# Altium schematic sheet MCU_SysBoard.SchDoc(MCU_SysBoard)
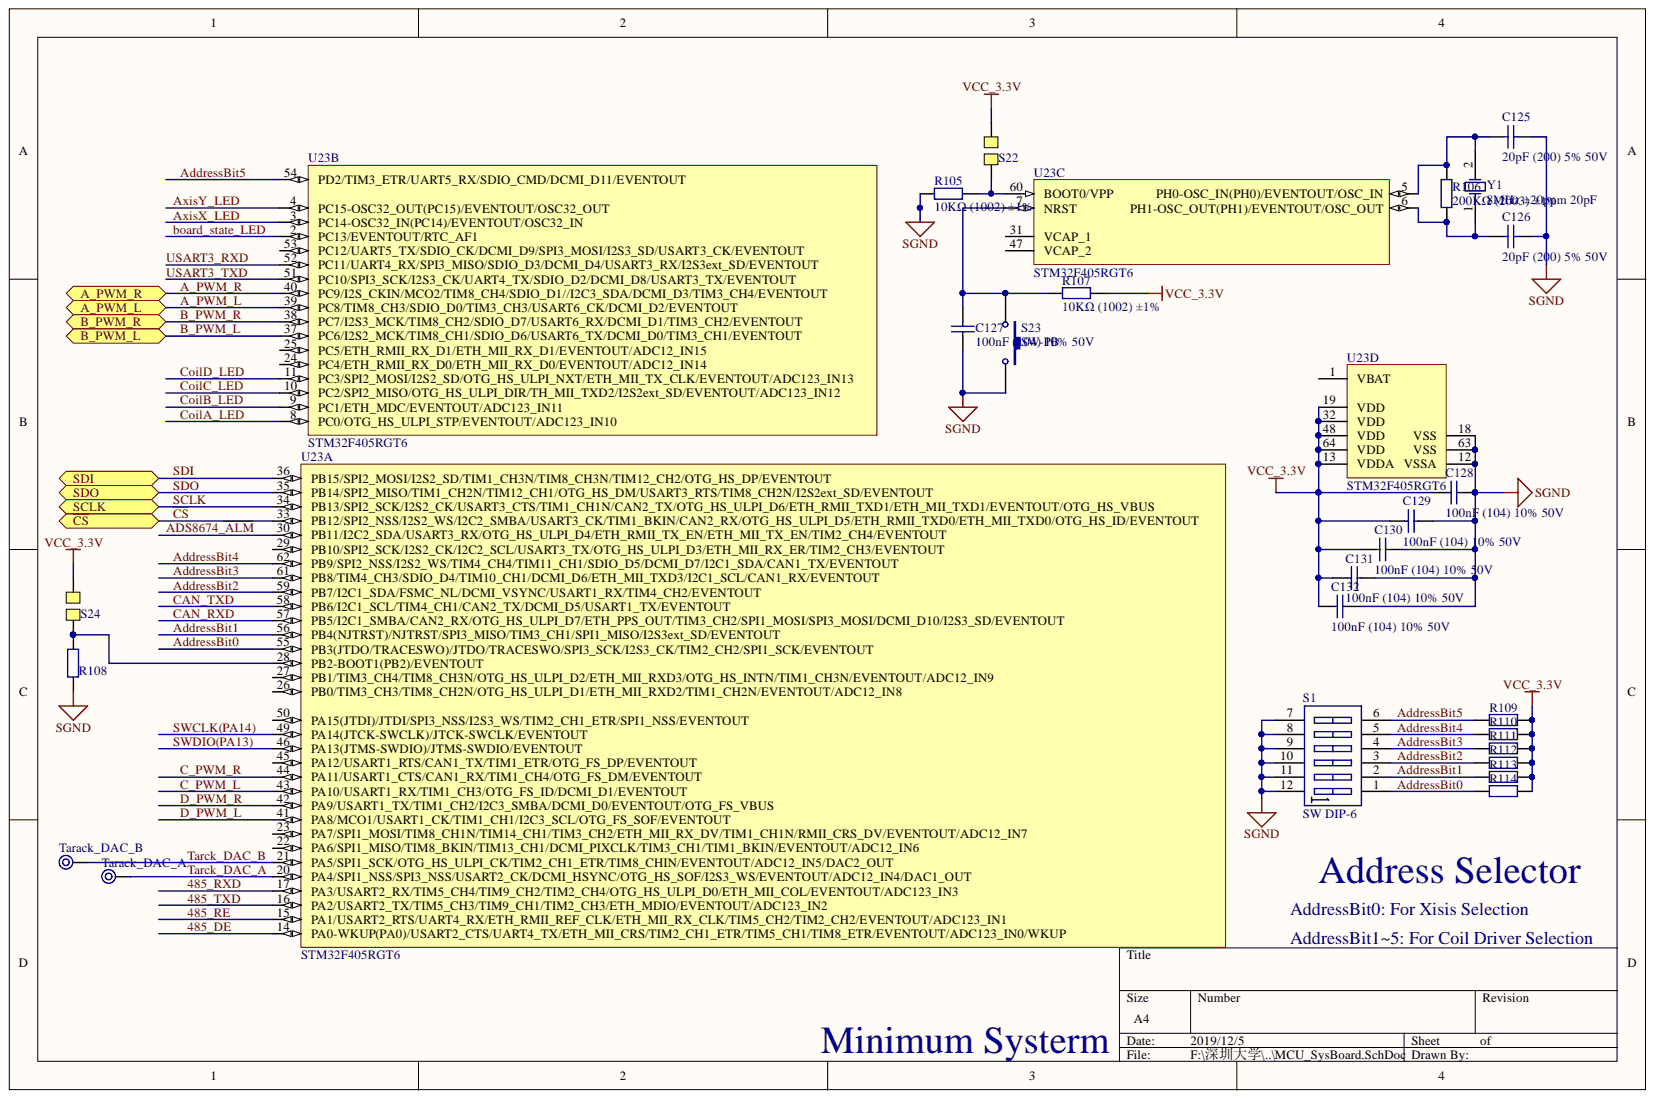
[diagram p0: MCU_SysBoard.SchDoc]

## Parts
C125  20pF (200) 5% 50V  [0402_C]
C126  20pF (200) 5% 50V  [0402_C]
C127  100nF (104) 10% 50V  [0603_C]
C128  100nF (104) 10% 50V  [0603_C]
C129  100nF (104) 10% 50V  [0603_C]
C130  100nF (104) 10% 50V  [0603_C]
C131  100nF (104) 10% 50V  [0603_C]
C132  100nF (104) 10% 50V  [0603_C]
R105  10KΩ (1002) ±1%  [0402_R]
R106  200KΩ (2003) ±1%  [0402_R]
R107  10KΩ (1002) ±1%  [0603_R]
R108  10KΩ (1002) ±1%  [0402_R]
R109  10KΩ (1002) ±1%  [0603_R]
R110  10KΩ (1002) ±1%  [0603_R]
R111  10KΩ (1002) ±1%  [0603_R]
R112  10KΩ (1002) ±1%  [0603_R]
R113  10KΩ (1002) ±1%  [0603_R]
R114  10KΩ (1002) ±1%  [0603_R]
S1  SW DIP-6  [DIP-12/SW]
S22  SHORT-2PIN  [SHORT-2PIN]  (value not drawn)
S23  SW-PB  [SW_PB2_A1]
S24  SHORT-2PIN  [SHORT-2PIN]  (value not drawn)
Tarack_DAC_A  TP_Surface  [TEST_POINT_ME]  (value not drawn)
Tarack_DAC_B  TP_Surface  [TEST_POINT_ME]  (value not drawn)
U23  STM32F405RGT6  [LQFP-64_10x10x05P]  (parts A,B,C,D)
Y1  8MHz ±20ppm 20pF  [SMD-5032_2P]

## Ports
A_PWM_L, A_PWM_R, B_PWM_L, B_PWM_R, SCLK, SDI, SDO, ~{CS}

## Nets
SGND: C125.2 C126.2 C127.2 C128.1 C129.1 C130.1 C131.1 C132.1 R105.1 R108.1 S1.7 S1.8 S1.9 S1.10 S1.11 S1.12 S23.2 U23.12(VSSA) U23.18(VSS) U23.63(VSS)
VCC_3.3V: C128.2 C129.2 C130.2 C131.2 C132.2 R107.2 R109.1 R110.1 R111.1 R112.1 R113.1 R114.1 S22.2 S24.2 U23.13(VDDA) U23.19(VDD) U23.32(VDD) U23.48(VDD) U23.64(VDD)
485_DE: U23.14(PA0-WKUP(PA0)/USART2_CTS/UART4_TX/ETH_MII_CRS/TIM2_CH1_ETR/TIM5_CH1/TIM8_ETR/EVENTOUT/ADC123_IN0/WKUP)
485_~{RE}: U23.15(PA1/USART2_RTS/UART4_RX/ETH_RMII_REF_CLK/ETH_MII_RX_CLK/TIM5_CH2/TIM2_CH2/EVENTOUT/ADC123_IN1)
485_RXD: U23.17(PA3/USART2_RX/TIM5_CH4/TIM9_CH2/TIM2_CH4/OTG_HS_ULPI_D0/ETH_MII_COL/EVENTOUT/ADC123_IN3)
485_TXD: U23.16(PA2/USART2_TX/TIM5_CH3/TIM9_CH1/TIM2_CH3/ETH_MDIO/EVENTOUT/ADC123_IN2)
A_PWM_L: U23.39(PC8/TIM8_CH3/SDIO_D0/TIM3_CH3/USART6_CK/DCMI_D2/EVENTOUT) ; port A_PWM_L
A_PWM_R: U23.40(PC9/I2S_CKIN/MCO2/TIM8_CH4/SDIO_D1//I2C3_SDA/DCMI_D3/TIM3_CH4/EVENTOUT) ; port A_PWM_R
AddressBit0: R114.2 S1.1 U23.55(PB3(JTDO/TRACESWO)/JTDO/TRACESWO/SPI3_SCK/I2S3_CK/TIM2_CH2/SPI1_SCK/EVENTOUT)
AddressBit1: R113.2 S1.2 U23.56(PB4(NJTRST)/NJTRST/SPI3_MISO/TIM3_CH1/SPI1_MISO/I2S3ext_SD/EVENTOUT)
AddressBit2: R112.2 S1.3 U23.59(PB7/I2C1_SDA/FSMC_NL/DCMI_VSYNC/USART1_RX/TIM4_CH2/EVENTOUT)
AddressBit3: R111.2 S1.4 U23.61(PB8/TIM4_CH3/SDIO_D4/TIM10_CH1/DCMI_D6/ETH_MII_TXD3/I2C1_SCL/CAN1_RX/EVENTOUT)
AddressBit4: R110.2 S1.5 U23.62(PB9/SPI2_NSS/I2S2_WS/TIM4_CH4/TIM11_CH1/SDIO_D5/DCMI_D7/I2C1_SDA/CAN1_TX/EVENTOUT)
AddressBit5: R109.2 S1.6 U23.54(PD2/TIM3_ETR/UART5_RX/SDIO_CMD/DCMI_D11/EVENTOUT)
ADS8674_~{ALM}: U23.30(PB11/I2C2_SDA/USART3_RX/OTG_HS_ULPI_D4/ETH_RMII_TX_EN/ETH_MII_TX_EN/TIM2_CH4/EVENTOUT)
AxisX_LED: U23.3(PC14-OSC32_IN(PC14)/EVENTOUT/OSC32_IN)
AxisY_LED: U23.4(PC15-OSC32_OUT(PC15)/EVENTOUT/OSC32_OUT)
B_PWM_L: U23.37(PC6/I2S2_MCK/TIM8_CH1/SDIO_D6/USART6_TX/DCMI_D0/TIM3_CH1/EVENTOUT) ; port B_PWM_L
B_PWM_R: U23.38(PC7/I2S3_MCK/TIM8_CH2/SDIO_D7/USART6_RX/DCMI_D1/TIM3_CH2/EVENTOUT) ; port B_PWM_R
board_state_LED: U23.2(PC13/EVENTOUT/RTC_AF1)
~{CS}: U23.33(PB12/SPI2_NSS/I2S2_WS/I2C2_SMBA/USART3_CK/TIM1_BKIN/CAN2_RX/OTG_HS_ULPI_D5/ETH_RMII_TXD0/ETH_MII_TXD0/OTG_HS_ID/EVENTOUT) ; port ~{CS}
C_PWM_L: U23.43(PA10/USART1_RX/TIM1_CH3/OTG_FS_ID/DCMI_D1/EVENTOUT)
C_PWM_R: U23.44(PA11/USART1_CTS/CAN1_RX/TIM1_CH4/OTG_FS_DM/EVENTOUT)
CAN_RXD: U23.57(PB5/I2C1_SMBA/CAN2_RX/OTG_HS_ULPI_D7/ETH_PPS_OUT/TIM3_CH2/SPI1_MOSI/SPI3_MOSI/DCMI_D10/I2S3_SD/EVENTOUT)
CAN_TXD: U23.58(PB6/I2C1_SCL/TIM4_CH1/CAN2_TX/DCMI_D5/USART1_TX/EVENTOUT)
CoilA_LED: U23.8(PC0/OTG_HS_ULPI_STP/EVENTOUT/ADC123_IN10)
CoilB_LED: U23.9(PC1/ETH_MDC/EVENTOUT/ADC123_IN11)
CoilC_LED: U23.10(PC2/SPI2_MISO/OTG_HS_ULPI_DIR/TH_MII_TXD2/I2S2ext_SD/EVENTOUT/ADC123_IN12)
CoilD_LED: U23.11(PC3/SPI2_MOSI/I2S2_SD/OTG_HS_ULPI_NXT/ETH_MII_TX_CLK/EVENTOUT/ADC123_IN13)
D_PWM_L: U23.41(PA8/MCO1/USART1_CK/TIM1_CH1/I2C3_SCL/OTG_FS_SOF/EVENTOUT)
D_PWM_R: U23.42(PA9/USART1_TX/TIM1_CH2/I2C3_SMBA/DCMI_D0/EVENTOUT/OTG_FS_VBUS)
SCLK: U23.34(PB13/SPI2_SCK/I2S2_CK/USART3_CTS/TIM1_CH1N/CAN2_TX/OTG_HS_ULPI_D6/ETH_RMII_TXD1/ETH_MII_TXD1/EVENTOUT/OTG_HS_VBUS) ; port SCLK
SDI: U23.36(PB15/SPI2_MOSI/I2S2_SD/TIM1_CH3N/TIM8_CH3N/TIM12_CH2/OTG_HS_DP/EVENTOUT) ; port SDI
SDO: U23.35(PB14/SPI2_MISO/TIM1_CH2N/TIM12_CH1/OTG_HS_DM/USART3_RTS/TIM8_CH2N/I2S2ext_SD/EVENTOUT) ; port SDO
SWCLK(PA14): U23.49(PA14(JTCK-SWCLK)/JTCK-SWCLK/EVENTOUT)
SWDIO(PA13): U23.46(PA13(JTMS-SWDIO)/JTMS-SWDIO/EVENTOUT)
Tarck_DAC_A: Tarack_DAC_A.1 U23.20(PA4/SPI1_NSS/SPI3_NSS/USART2_CK/DCMI_HSYNC/OTG_HS_SOF/I2S3_WS/EVENTOUT/ADC12_IN4/DAC1_OUT)
Tarck_DAC_B: Tarack_DAC_B.1 U23.21(PA5/SPI1_SCK/OTG_HS_ULPI_CK/TIM2_CH1_ETR/TIM8_CHIN/EVENTOUT/ADC12_IN5/DAC2_OUT)
USART3_RXD: U23.52(PC11/UART4_RX/SPI3_MISO/SDIO_D3/DCMI_D4/USART3_RX/I2S3ext_SD/EVENTOUT)
USART3_TXD: U23.51(PC10/SPI3_SCK/I2S3_CK/UART4_TX/SDIO_D2/DCMI_D8/USART3_TX/EVENTOUT)
NetC125_1: C125.1 R106.2 U23.5(PH0-OSC_IN(PH0)/EVENTOUT/OSC_IN) Y1.2
NetC126_1: C126.1 R106.1 U23.6(PH1-OSC_OUT(PH1)/EVENTOUT/OSC_OUT) Y1.1
NetC127_1: C127.1 R107.1 S23.1 U23.7(NRST)
NetR105_2: R105.2 S22.1 U23.60(BOOT0/VPP)
NetR108_2: R108.2 S24.1 U23.28(PB2-BOOT1(PB2)/EVENTOUT)

Not connected: U23 1(VBAT) 22(PA6/SPI1_MISO/TIM8_BKIN/TIM13_CH1/DCMI_PIXCLK/TIM3_CH1/TIM1_BKIN/EVENTOUT/ADC12_IN6) 23(PA7/SPI1_MOSI/TIM8_CH1N/TIM14_CH1/TIM3_CH2/ETH_MII_RX_DV/TIM1_CH1N/RMII_CRS_DV/EVENTOUT/ADC12_IN7) 24(PC4/ETH_RMII_RX_D0/ETH_MII_RX_D0/EVENTOUT/ADC12_IN14) 25(PC5/ETH_RMII_RX_D1/ETH_MII_RX_D1/EVENTOUT/ADC12_IN15) 26(PB0/TIM3_CH3/TIM8_CH2N/OTG_HS_ULPI_D1/ETH_MII_RXD2/TIM1_CH2N/EVENTOUT/ADC12_IN8) 27(PB1/TIM3_CH4/TIM8_CH3N/OTG_HS_ULPI_D2/ETH_MII_RXD3/OTG_HS_INTN/TIM1_CH3N/EVENTOUT/ADC12_IN9) 29(PB10/SPI2_SCK/I2S2_CK/I2C2_SCL/USART3_TX/OTG_HS_ULPI_D3/ETH_MII_RX_ER/TIM2_CH3/EVENTOUT) 31(VCAP_1) 45(PA12/USART1_RTS/CAN1_TX/TIM1_ETR/OTG_FS_DP/EVENTOUT) 47(VCAP_2) 50(PA15(JTDI)/JTDI/SPI3_NSS/I2S3_WS/TIM2_CH1_ETR/SPI1_NSS/EVENTOUT) 53(PC12/UART5_TX/SDIO_CK/DCMI_D9/SPI3_MOSI/I2S3_SD/USART3_CK/EVENTOUT)

## Library data of the parts
C125 C126 = 0402 20pF (200) 5% 50V: 贴片电容; SuppliersPartNumber: C1554; Supplier: LC; Package: 0402; Library Name: JLC Library.IntLib; ComponentLink1URL: http://www.szlcsc.com/product/details_1906.html; ComponentLink1Description: 供应商链接; Component Kind: Standard
C127-C132 = 0603 100nF (104) 10% 50V: 贴片电容; SuppliersPartNumber: C14663; Supplier: LC; Package: 0603; Library Name: JLC Library.IntLib; ComponentLink1URL: http://www.szlcsc.com/product/details_15331.html; ComponentLink1Description: 供应商链接; Component Kind: Standard
R105 R108 = 0402 10KΩ (1002) 1%: 贴片电阻; SuppliersPartNumber: C25744; Supplier: LC; Package: 0402; Library Name: JLC Library.IntLib; ComponentLink1URL: http://www.szlcsc.com/product/details_26487.html; ComponentLink1Description: 供应商链接; Component Kind: Standard
R106 = 0402 200KΩ (2003) 1%: 贴片电阻; SuppliersPartNumber: C25764; Supplier: LC; Package: 0402; Library Name: JLC Library.IntLib; ComponentLink1URL: http://www.szlcsc.com/product/details_26507.html; ComponentLink1Description: 供应商链接; Component Kind: Standard
R107 R109-R114 = 0603 10KΩ (1002) 1%: 贴片电阻; SuppliersPartNumber: C25804; Supplier: LC; Package: 0603; Library Name: JLC Library.IntLib; ComponentLink1URL: http://www.szlcsc.com/product/details_26547.html; ComponentLink1Description: 供应商链接; Component Kind: Standard
S1 = SW DIP-6: DIP Switch, 6 Position, SPST; Simulation: dpsw6; Published: 24-Mar-1999; PackageReference: DIP-12/SW; PackageDescription: DIP Switch; 12 Leads; Library Name: Miscellaneous Devices.IntLib; LatestRevisionNote: Re-released for DXP Platform.; LatestRevisionDate: 17-Jul-2002; Component Kind: Standard; Publisher: Altium Limited
S23 = SW-PB: 微动开关; Published: 24-Mar-1999; PackageReference: SPST-2; LatestRevisionNote: Re-released for DXP Platform.; LatestRevisionDate: 17-Jul-2002; Component Kind: Standard; Publisher: Altium Limited; Library Name: 接插件集成库.IntLib
U23 = STM32F405RGT6: ARM; SuppliersPartNumber: C15742; Supplier: LC; Package: LQFP-64_10x10x05P; Library Name: JLC Library.IntLib; ComponentLink1URL: http://www.szlcsc.com/product/details_16424.html; ComponentLink1Description: 供应商链接; Component Kind: Standard
Y1 = SMD-5032_2P 8MHz 20ppm 20pF: 贴片晶振; SuppliersPartNumber: C115962; Supplier: LC; Package: SMD-5032_2P; Library Name: JLC Library.IntLib; ComponentLink1URL: http://www.szlcsc.com/product/details_117214.html; ComponentLink1Description: 供应商链接; Component Kind: Standard
S22 S24 = SHORT-2PIN: Library Name: HY.SchLib; Component Kind: Standard
Tarack_DAC_A Tarack_DAC_B = TP_Surface: Component Kind: Standard; Library Name: PSRM_Sensorless_V2.0模块.IntLib
